# Altium schematic sheet Connectors.SchDoc
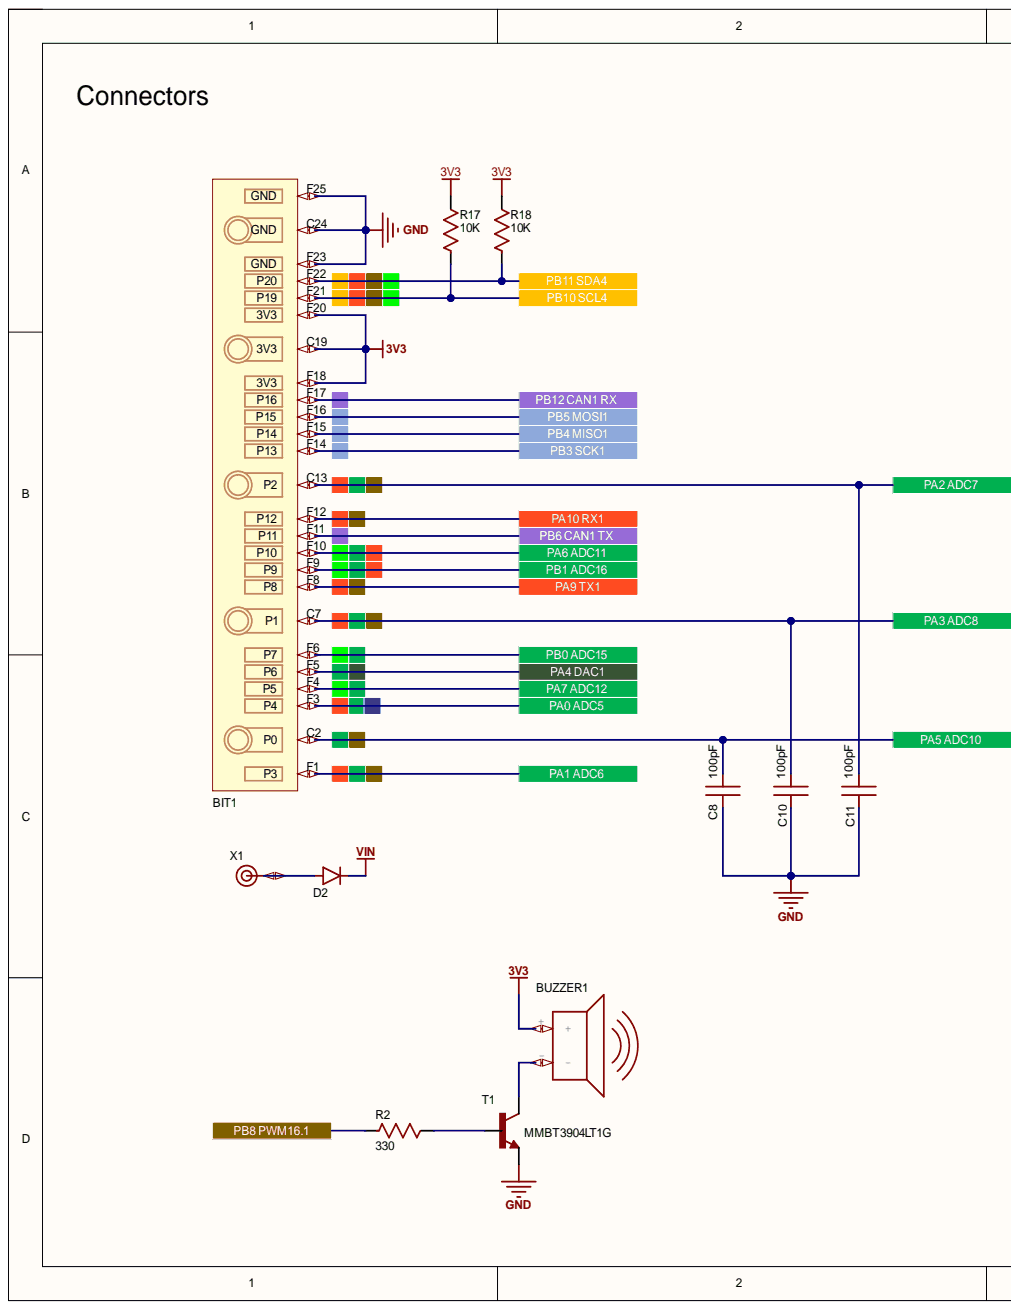
[diagram p0: Connectors.SchDoc, left]
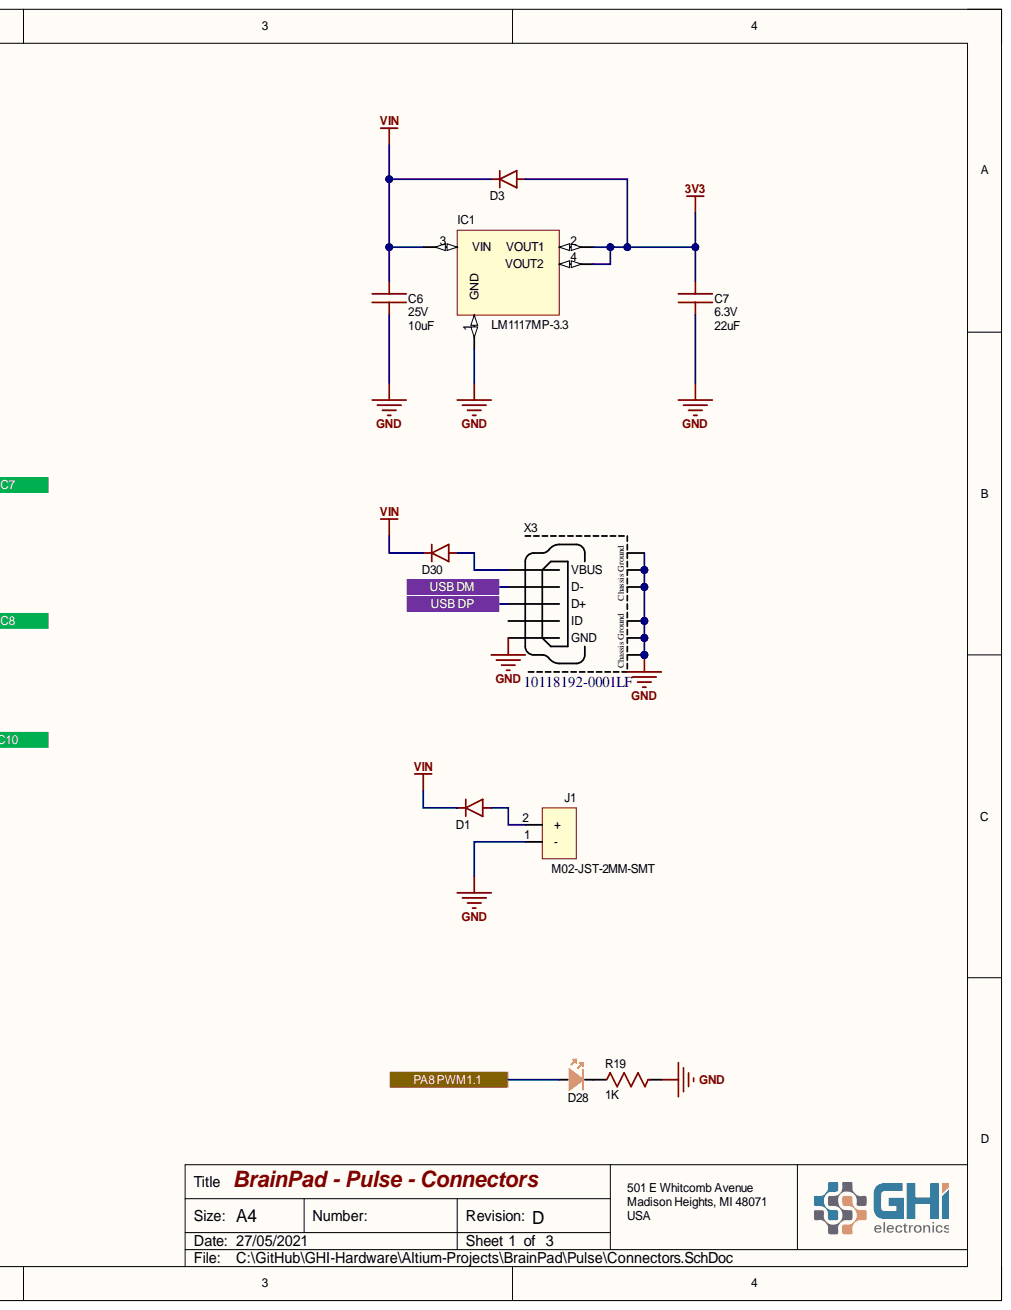
[diagram p1: Connectors.SchDoc, right]

## Parts
BIT1  BIT-EDGE-X  [BIT-EDGE-X]  (value not drawn)
BUZZER1  Buzzer - Small  [KLJ-SMD-BUZZER]  (value not drawn)
C6  value 10uF  Voltage: 25V  [C0805 L]  (hidden comment: 0805 Cap 10uF)
C7  value 22uF  Capacitance: 22uF  Voltage: 6.3V  [C0805 L]  (hidden comment: 0805 Cap 22uF)
C8  value 100pF  Capacitance: 100pF  [C0603 (1608 Metric)]  (hidden comment: 0603 Cap)
C10  value 100pF  Capacitance: 100pF  [C0603 (1608 Metric)]  (hidden comment: 0603 Cap)
C11  value 100pF  Capacitance: 100pF  [C0603 (1608 Metric)]  (hidden comment: 0603 Cap)
D1  MSS1P2L-M3/89A  [MicroSMP]  (value not drawn)
D2  MSS1P2L-M3/89A  [MicroSMP]  (value not drawn)
D3  MSS1P2L-M3/89A  [MicroSMP]  (value not drawn)
D28  0603 LED Orange  [0603 LED Orange]  (value not drawn)
D30  MSS1P2L-M3/89A  [MicroSMP]  (value not drawn)
IC1  value LM1117MP-3.3  [SOT223]
J1  M02-JST-2MM-SMT  [JST-2-SMD]
R2  value 330  [R0603 (1608 Metric)]  (hidden comment: 0603 330)
R17  value 10K  Resistance: 10K  [R0402]  (hidden comment: 0402 Res 10K)
R18  value 10K  Resistance: 10K  [R0402]  (hidden comment: 0402 Res 10K)
R19  value 1K  Resistance: 1K  [R0603 (1608 Metric)]  (hidden comment: 0603 1K)
T1  3904  value MMBT3904LT1G  [SOT23]
X1  BIT-C-PAD  [BIT-C-PAD]  (value not drawn)
X3  10118192-0001LF  [10118192-0001LF (Micro USB B Amphenol FCI)]

## Ports
PA0 ADC5, PA1 ADC6, PA2 ADC7, PA3 ADC8, PA4 DAC1, PA5 ADC10, PA6 ADC11, PA7 ADC12, PA8 PWM1.1, PA9 TX1, PA10 RX1, PB0 ADC15, PB1 ADC16, PB3 SCK1, PB4 MISO1, PB5 MOSI1, PB6 CAN1 TX, PB8 PWM16.1, PB10 SCL4, PB11 SDA4, PB12 CAN1 RX, USB DM, USB DP

## Nets
3V3: BIT1.C19(3V3) BIT1.F18(3V3) BIT1.F20(3V3) BUZZER1.+ C7.2 D3.A IC1.2(VOUT1) IC1.4(VOUT2) R17.2 R18.2
GND: BIT1.C24(GND) BIT1.F23(GND) BIT1.F25(GND) C6.1 C7.1 C8.2 C10.2 C11.2 IC1.1(GND) J1.1 R19.1 T1.2 X3.5(GND) X3.CGND1 X3.CGND2 X3.CGND3 X3.CGND4 X3.CGND5 X3.CGND6
VIN: C6.2 D1.C D2.C D3.C D30.C IC1.3(VIN)
PA0 ADC5: BIT1.F3(P4) ; port PA0 ADC5
PA1 ADC6: BIT1.F1(P3) ; port PA1 ADC6
PA2 ADC7: BIT1.C13(P2) C11.1 ; port PA2 ADC7
PA3 ADC8: BIT1.C7(P1) C10.1 ; port PA3 ADC8
PA4 DAC1: BIT1.F5(P6) ; port PA4 DAC1
PA5 ADC10: BIT1.C2(P0) C8.1 ; port PA5 ADC10
PA6 ADC11: BIT1.F10(P10) ; port PA6 ADC11
PA7 ADC12: BIT1.F4(P5) ; port PA7 ADC12
PA8 PWM1.1: D28.2 ; port PA8 PWM1.1
PA9 TX1: BIT1.F8(P8) ; port PA9 TX1
PA10 RX1: BIT1.F12(P12) ; port PA10 RX1
PB0 ADC15: BIT1.F6(P7) ; port PB0 ADC15
PB1 ADC16: BIT1.F9(P9) ; port PB1 ADC16
PB3 SCK1: BIT1.F14(P13) ; port PB3 SCK1
PB4 MISO1: BIT1.F15(P14) ; port PB4 MISO1
PB5 MOSI1: BIT1.F16(P15) ; port PB5 MOSI1
PB6 CAN1 TX: BIT1.F11(P11) ; port PB6 CAN1 TX
PB8 PWM16.1: R2.1 ; port PB8 PWM16.1
PB10 SCL4: BIT1.F21(P19) R17.1 ; port PB10 SCL4
PB11 SDA4: BIT1.F22(P20) R18.1 ; port PB11 SDA4
PB12 CAN1 RX: BIT1.F17(P16) ; port PB12 CAN1 RX
USB DM: X3.2(D-) ; port USB DM
USB DP: X3.3(D+) ; port USB DP
NetBUZZER1_-: BUZZER1.- T1.3
NetD1_A: D1.A J1.2
NetD2_A: D2.A X1.1
NetD28_1: D28.1 R19.2
NetD30_A: D30.A X3.1(VBUS)
NetR2_2: R2.2 T1.1

Not connected: X3 4(ID)

## Library data of the parts
BIT1 = BIT-EDGE-X: BIT is the complete dimension with the edge. BIT-EDGE is only the edge connector of the BIT. BIT-EDGE-X is a simplified pad configuration for the BIT.; GateName_1: G$1; Component Kind: Standard; DeviceName: -EDGE-X; DeviceSetName: BIT; SymbolName_1: BIT; Library Name: GHI-Connectors.SchLib; LibraryName: GHI-Solutions
BUZZER1 = KLJ-8530-5027: Piezo Speaker from CHANGZHOU KELIKING ELECTRONICS CO., LTD; Component Kind: Standard; PartNo: KLJ-8530-5027; Value: KLJ-8530-5027; Library Name: GHI.SchLib
C6 = 0805 Cap 10uF: 10uF ±10% 25V X5R 0805 Multilayer Ceramic Capacitors MLCC - SMD/SMT RoHS; Manufacturer: YAGEO; Mfr.Part #: CC0805KKX5R8BB106; Capacitance: 10uF; Category: Ceramic; Component Kind: Standard; LCSC Part #: C89831; Package: 0805; Tolerance: ±10%; Value: 10uF; Library Name: IM-Passives.SchLib
C7 = 0805 Cap 22uF: 22uF ±20% 6.3V X5R 0805 Multilayer Ceramic Capacitors MLCC - SMD/SMT RoHS; Mfr.Part #: CL21A226MQCLRNC; Category: Ceramic; Component Kind: Standard; LCSC Part #: C307530; Package: 0805; Tolerance: ±20%; Library Name: GHI-Passives.SchLib
C8 C10 C11 = 0603 Capacitor (Metric 1608): Capacitor 0603 Generic; Capacitor Class: Ceramic; Voltage: 50V; Capacitance Tolerance: N/A; Component Kind: Standard; Component Type: Capacitor; Package: 0603; Library Name: GHI.SchLib
D1-D3 D30 = MSS1P2L-M3/89A: 20V 1A 500mV @ 1A MicroSMP(DO-219AD) Schottky Barrier Diodes (SBD) RoHS; Manufacturer: Vishay Intertech; Category: Schottky Barrier Diodes (SBD); Component Kind: Standard; ComponentLink1Description: http://www.vishay.com/docs/89020/mss1p3l.pdf; ComponentLink1URL: http://www.vishay.com/docs/89020/mss1p3l.pdf; Digikey Part #: MSS1P2L-M3/89AGICT-ND; LCSC Part #: C506621; Mfr.Part #: MSS1P2L-M3/89A; Package: MicroSMP(DO-219AD); Value: MSS1P2L-M3/89A; Library Name: GHI-Power.SchLib
D28 = 0603 LED Orange: Orange 605nm 0603 Light Emitting Diodes (LED) RoHS; Manufacturer: Lite-On; Mfr.Part #: LTST-C190KFKT; Color: Orange; Component Kind: Standard; LCSC Part #: C157740; Package: 0603; Value: 0603 LED Orange; Library Name: GHI-Opto.SchLib
IC1 = LM1117MPX-3.3: IC REG LIN 3.3V 800MA SOT223-4; DeviceSetName: LM1117; GateName_1: G$1; Component Kind: Standard; SymbolName_1: LM1117; Library Name: GHI.SchLib; LibraryName: GHI
R2 = 0603 Res 330: 330 ±5% 1/10W ±100ppm/℃ 0603 Chip Resistor - Surface Mount RoHS; Manufacturer: Walsin Tech Corp; Mfr.Part #: WR06X331 JTL; Voltage Rating: *V; Component Kind: Standard; LCSC Part #: C384242; Package: 0603; Power Rating: 1/10W; Resistance: 330; Resistance Tolerance: ±5%; Library Name: GHI-Passives.SchLib
R17 R18 = 0402 Res 10K: 10K ±1% 1/16W ±100ppm/℃ 0402 Chip Resistor - Surface Mount RoHS; Manufacturer: YAGEO; Mfr.Part #: RC0402FR-0710KL; Voltage Rating: *V; Component Kind: Standard; LCSC Part #: C60490; Package: 0402; Power Rating: 1/16W; Resistance Tolerance: ±1%; Library Name: GHI-Passives.SchLib
R19 = 0603 Res 1K: 1K ±5% 1/10W ±100ppm/℃ 0603 Chip Resistor - Surface Mount RoHS; Manufacturer: Walsin Tech Corp; Mfr.Part #: WR06X102 JTL; Voltage Rating: *V; Component Kind: Standard; LCSC Part #: C384295; Package: 0603; Power Rating: 1/10W; Resistance Tolerance: ±5%; Library Name: GHI-Passives.SchLib
T1 = MMBT3904LT1G: NPN 200mA 40V 300mW SOT-23(SOT-23-3) Transistors (NPN/PNP) RoHS; Manufacturer: ON Semicon; Component Kind: Standard; LCSC Part #: C81464; Mfr.Part #: MMBT3904LT1G; Package: SOT-23(SOT-23-3); Library Name: GHI-Semiconductors.SchLib
X1 = BIT-C-PAD: GateName_1: G$1; Component Kind: Standard; DeviceSetName: BIT-C-PAD; SymbolName_1: BIT-C-PAD; Library Name: GHI-Connectors.SchLib; LibraryName: GHI-SpecialPads
X3 = 10118192-0001LF: Amphenol FCI Micro USB Type B Complete Surface Mount (SMT) no guide holes; Component Kind: Standard; Library Name: GHI.SchLib
J1 = M02-JST-2MM-SMT: Component Kind: Standard; Library Name: IM-Connectors.SchLib
